# Altium schematic sheet Communication.SchDoc
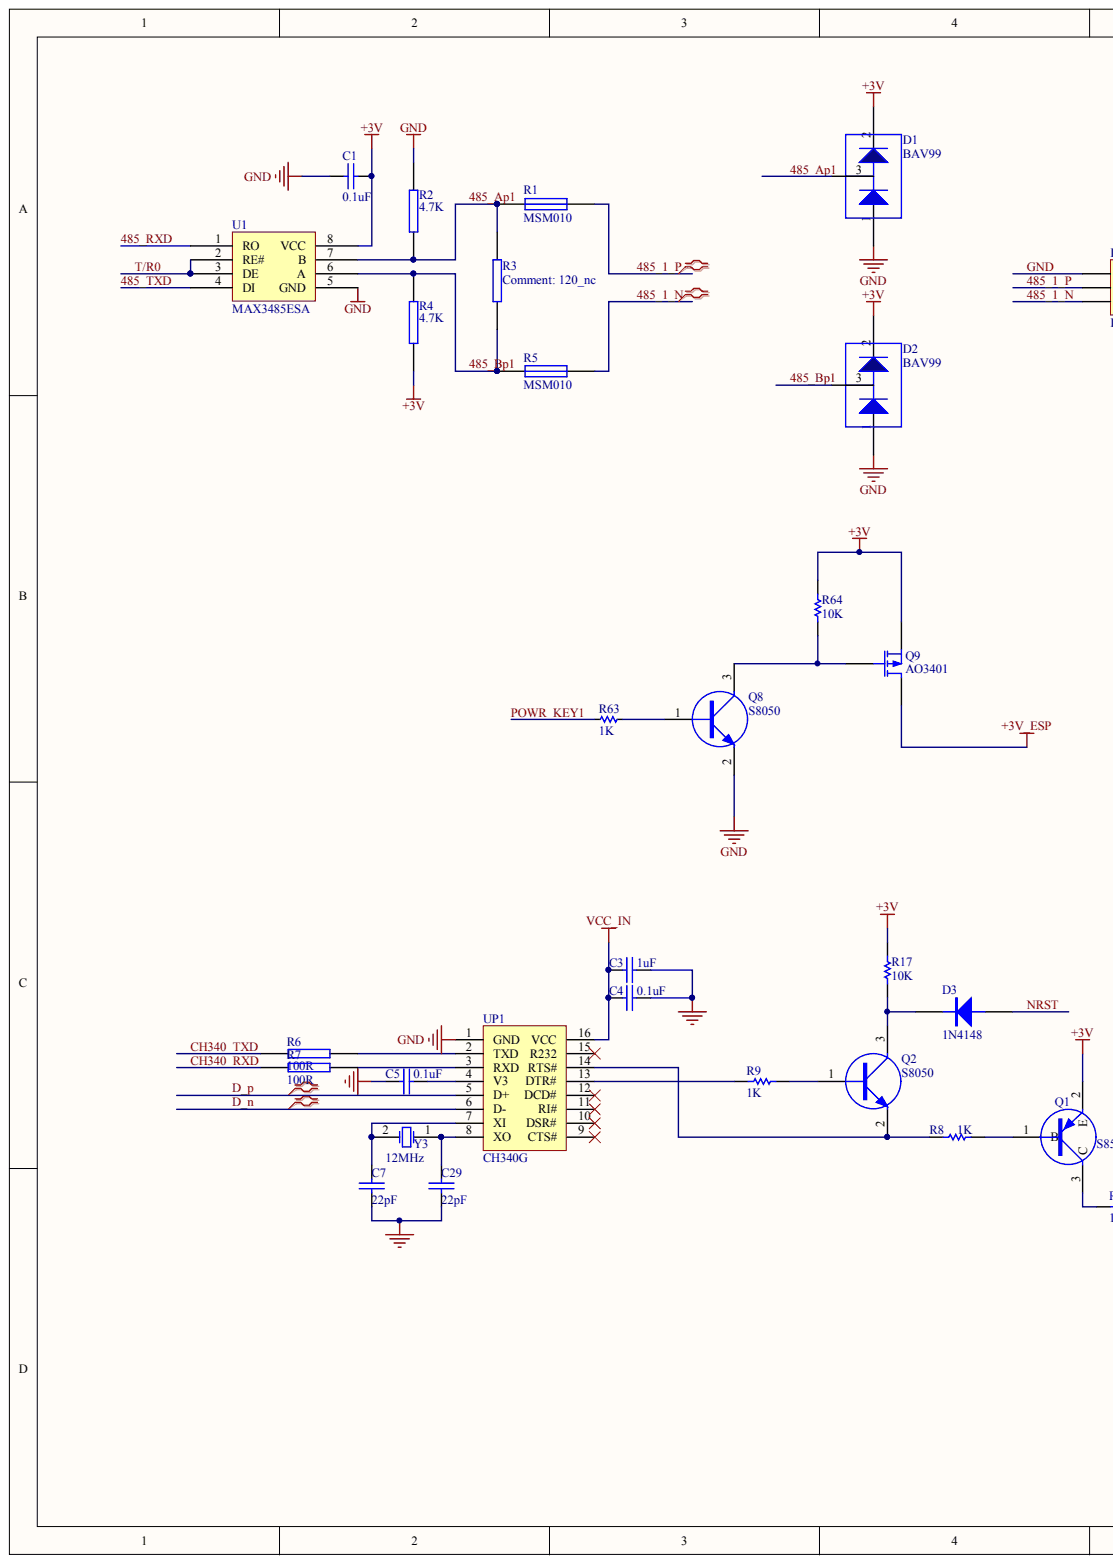
[diagram p0: Communication.SchDoc, left]
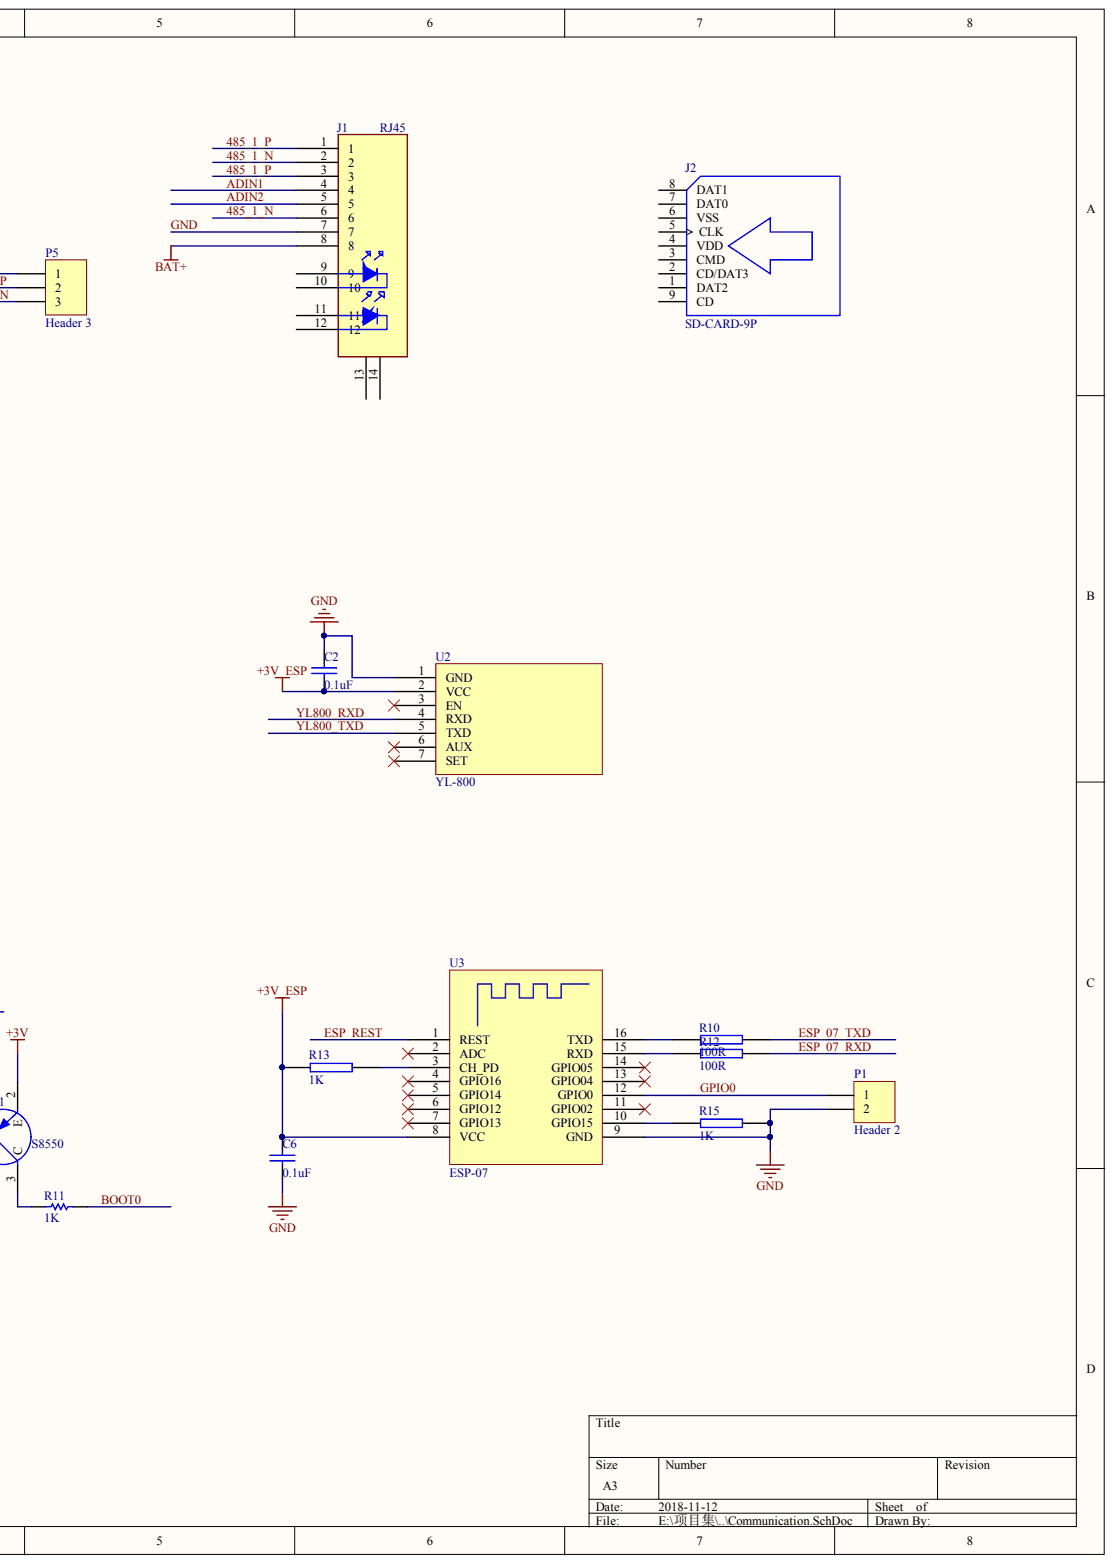
[diagram p1: Communication.SchDoc, right]

## Parts
C1  0.1uF  [0603C]
C2  0.1uF  [0603C]
C3  1uF  [0603C]
C4  0.1uF  [0603C]
C5  0.1uF  [0603C]
C6  0.1uF  [0603C]
C7  22pF  [0603C]
C29  22pF  [0603C]
D1  BAV99  [SOT-23]
D2  BAV99  [SOT-23]
D3  1N4148  [SOD-323]
J1  RJ45  [RJ45-1]
J2  SD-CARD-9P  [SDCARD-9P]
P1  Header 2  [HDR1X2]
P5  Header 3  [XH3]
Q1  S8550  [sot-23]
Q2  S8050  [SOT-23]
Q8  S8050  [SOT-23]
Q9  AO3401  [SOT-23]
R1  MSM010  [0603R]
R2  4.7K  [0603R]
R3  120_nc  [0603R]
R4  4.7K  [0603R]
R5  MSM010  [0603R]
R6  100R  [0603R]
R7  100R  [0603R]
R8  1K  [0603R]
R9  1K  [0603R]
R10  100R  [0603R]
R11  1K  [0603R]
R12  100R  [0603R]
R13  1K  [0603R]
R15  1K  [0603R]
R17  10K  [0603R]
R63  1K  [0603R]
R64  10K  [0603R]
U1  MAX3485ESA  [SO-8]
U2  YL-800  [YL-800N]
U3  ESP-07  [ESP-07]
UP1  CH340G  [SOIC-16_150mil]
Y3  12MHz  [SMD2_50X32]

## Nets
+3V: C1.2 D1.2 D2.2 Q1.2 Q9.2 R4.1 R17.2 R64.1 U1.8(VCC)
+3V_ESP: C2.1 C6.2 Q9.3 R13.1 U2.2(VCC) U3.8(VCC)
BAT+: J1.8
VCC_IN: C3.1 C4.1 UP1.16(VCC)
485_1_N: J1.2 J1.6 P5.3 R5.2
485_1_P: J1.1 J1.3 P5.2 R1.2
485_AP1: D1.3 R1.1 R2.1 R3.2 U1.7(B)
485_BP1: D2.3 R3.1 R4.2 R5.1 U1.6(A)
485_RXD: U1.1(RO)
485_TXD: U1.4(DI)
ADIN1: J1.4
ADIN2: J1.5
BOOT0: R11.1
CH340_RXD: R7.2
CH340_TXD: R6.2
D_N: UP1.6(D-)
D_P: UP1.5(D+)
ESP_07_RXD: R12.2
ESP_07_TXD: R10.2
ESP_REST: U3.1(REST)
GND: C1.1 C2.2 C3.2 C4.2 C5.1 C6.1 C7.2 C29.2 D1.1 D2.1 J1.7 P1.2 P5.1 Q8.2 R2.2 R15.2 U1.5(GND) U2.1(GND) U3.9(GND) UP1.1(GND)
GPIO0: P1.1 U3.12(GPIO0)
NRST: D3.1
POWR_KEY1: R63.1
T/R0: U1.2(RE#) U1.3(DE)
YL800_RXD: U2.4(RXD)
YL800_TXD: U2.5(TXD)
NetC5_2: C5.2 UP1.4(V3)
NetC7_1: C7.1 UP1.7(XI) Y3.2
NetC29_1: C29.1 UP1.8(XO) Y3.1
NetD3_2: D3.2 Q2.3 R17.1
NetQ1_1: Q1.1 R8.1
NetQ1_3: Q1.3 R11.2
NetQ2_1: Q2.1 R9.1
NetQ2_2: Q2.2 R8.2 UP1.14(RTS#)
NetQ8_1: Q8.1 R63.2
NetQ8_3: Q8.3 Q9.1 R64.2
NetR6_1: R6.1 UP1.2(TXD)
NetR7_1: R7.1 UP1.3(RXD)
NetR9_2: R9.2 UP1.13(DTR#)
NetR10_1: R10.1 U3.16(TXD)
NetR12_1: R12.1 U3.15(RXD)
NetR13_2: R13.2 U3.3(CH_PD)
NetR15_1: R15.1 U3.10(GPIO15)

Not connected: J1 9 10 11 12 13 14; J2 1(DAT2) 2(CD/DAT3) 3(CMD) 4(VDD) 5(CLK) 6(VSS) 7(DAT0) 8(DAT1) 9(CD); U2 3(EN) 6(AUX) 7(SET); U3 2(ADC) 4(GPIO16) 5(GPIO14) 6(GPIO12) 7(GPIO13) 11(GPIO02) 13(GPIO04) 14(GPIO05); UP1 9(CTS#) 10(DSR#) 11(RI#) 12(DCD#) 15(R232)

## Library data of the parts
C1 = CAP: Component Kind: Standard
C2-C7 C29 = CAP: Capacitor; Component Kind: Standard
D1 D2 = D_DIODE: Component Kind: Standard
D3 = DIODE: Component Kind: Standard; Library Name: My Devices.SCHLIB
P1 = Header 2: Header, 2-Pin; Component Kind: Standard; LatestRevisionDate: 17-Jul-2002; LatestRevisionNote: Re-released for DXP Platform.; Library Name: Miscellaneous Connectors.IntLib; Publisher: Altium Limited
P5 = Header 3: Header, 3-Pin; Component Kind: Standard; LatestRevisionDate: 17-Jul-2002; LatestRevisionNote: Re-released for DXP Platform.; Library Name: Miscellaneous Connectors.IntLib; Publisher: Altium Limited
Q1 = PNP: PNP Transistor; Component Kind: Standard
Q2 Q8 = NPN: Component Kind: Standard; Library Name: My Devices.SCHLIB
Q9 = PMOS: Component Kind: Standard; Library Name: My Devices.SCHLIB
R1 R5 = MSM010: MSM010-0805   自恢复保险丝; Text Field1: SEALAND; Text Field2: 英洛威; Text Field3: 630410004000; Text Field4: SMT; Component Kind: Standard
R2 R4 = 4.7K-C: 4.7K  5% 0805 1/16W; Component Kind: Standard
R3 R6 R7 R10 R12 R13 R15 = RES2: Component Kind: Standard
R8 R9 R11 = Res1: Resistor; Component Kind: Standard; LatestRevisionDate: 17-Jul-2002; LatestRevisionNote: Re-released for DXP Platform.; Library Name: Miscellaneous Devices.IntLib; PackageReference: AXIAL-0.3; Published: 8-Jun-2000; Simulation: RESISTOR; Value: 1K; Publisher: Altium Limited
R17 = Res1: Resistor; Value: 1K; Component Kind: Standard; LatestRevisionDate: 17-Jul-2002; LatestRevisionNote: Re-released for DXP Platform.; Library Name: Miscellaneous Devices.IntLib; PackageReference: AXIAL-0.3; Published: 8-Jun-2000; Simulation: RESISTOR; Publisher: Altium Limited
R63 = RES: Component Kind: Standard; Library Name: My Devices.SCHLIB
R64 = Res1: Resistor; Value: 100R; Component Kind: Standard; LatestRevisionDate: 17-Jul-2002; LatestRevisionNote: Re-released for DXP Platform.; Library Name: Miscellaneous Devices.IntLib; PackageReference: AXIAL-0.3; Published: 8-Jun-2000; Publisher: Altium Limited
U1 = MAX483ESA: Text Field1: 美信; Text Field2: 华强北浩光利; Text Field3: 610500048300; Text Field4: (-40°C to 85°C); Text Field5: 5.3RMB/PCS; Component Kind: Standard; Description: MAX483ESA
Y3 = XTAL: Crystal Oscillator; Component Kind: Standard; LatestRevisionDate: 17-Jul-2002; LatestRevisionNote: Re-released for DXP Platform.; Library Name: Miscellaneous Devices.IntLib; PackageReference: R38; Published: 8-Jun-2000; Simulation: XTAL; Publisher: Altium Limited
J1 = RJ45: Component Kind: Standard; Library Name: My Devices.SCHLIB
J2 = SD-CARD-9P: Component Kind: Standard; Library Name: My Devices.SCHLIB
U2 = YL-800: Component Kind: Standard; Library Name: My Devices.SCHLIB
U3 = ESP-07: Component Kind: Standard; Library Name: My Devices.SCHLIB
UP1 = CH340G: Component Kind: Standard; Library Name: My Devices.SCHLIB
